# Altium schematic sheet RF210C.SchDoc(RF210C)
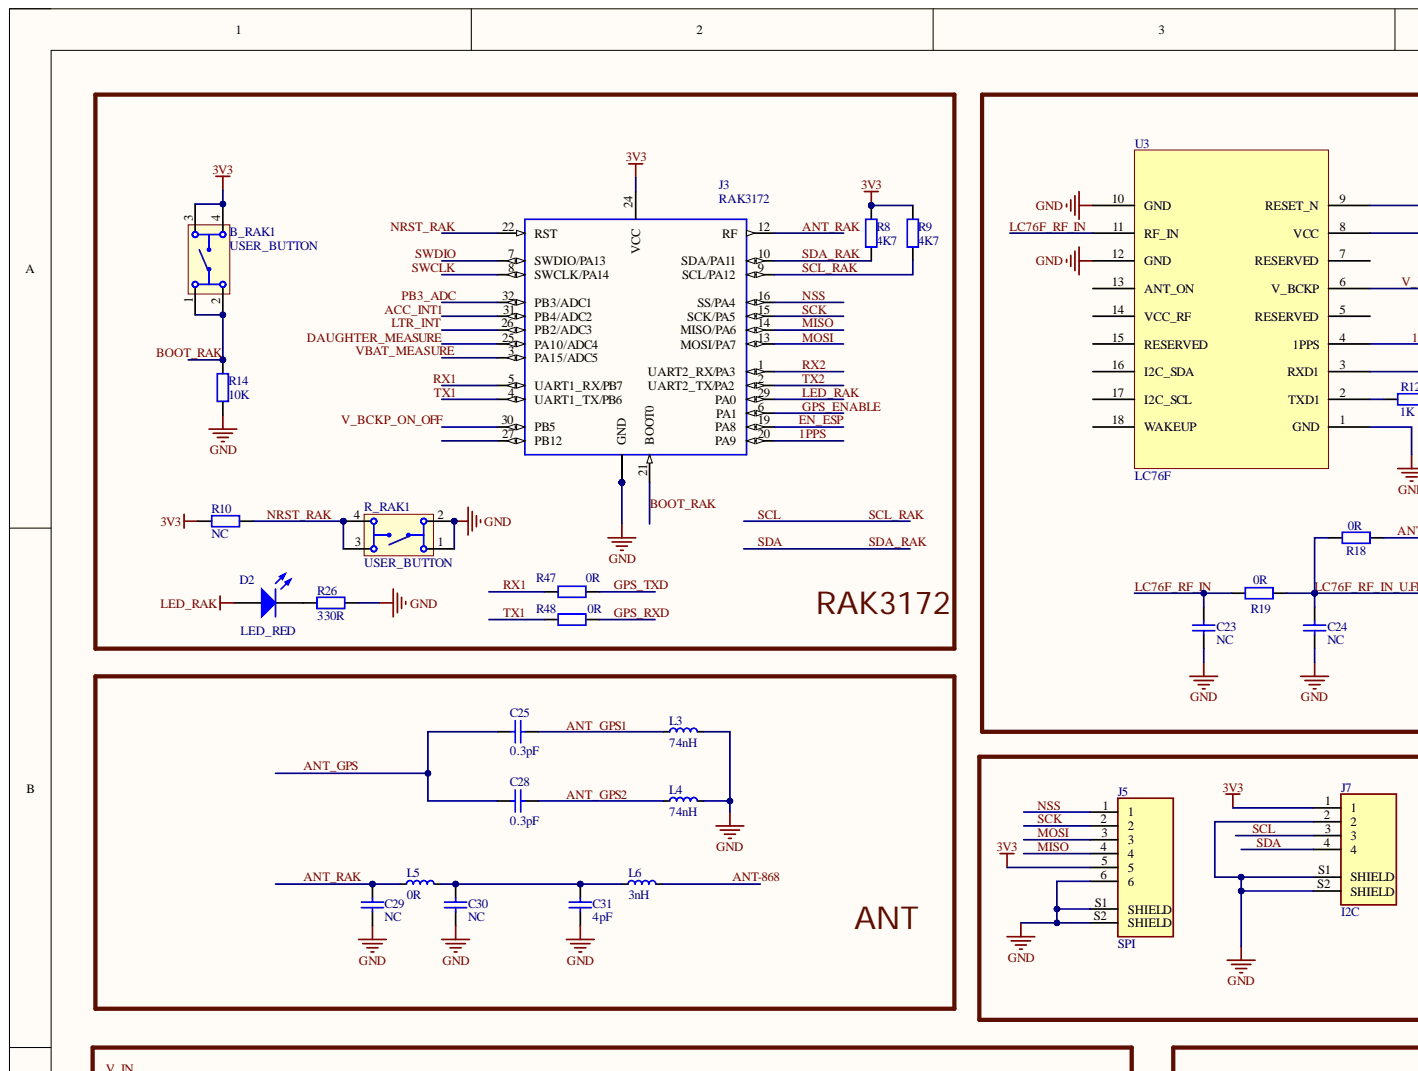
[diagram p0: RF210C.SchDoc, top left]
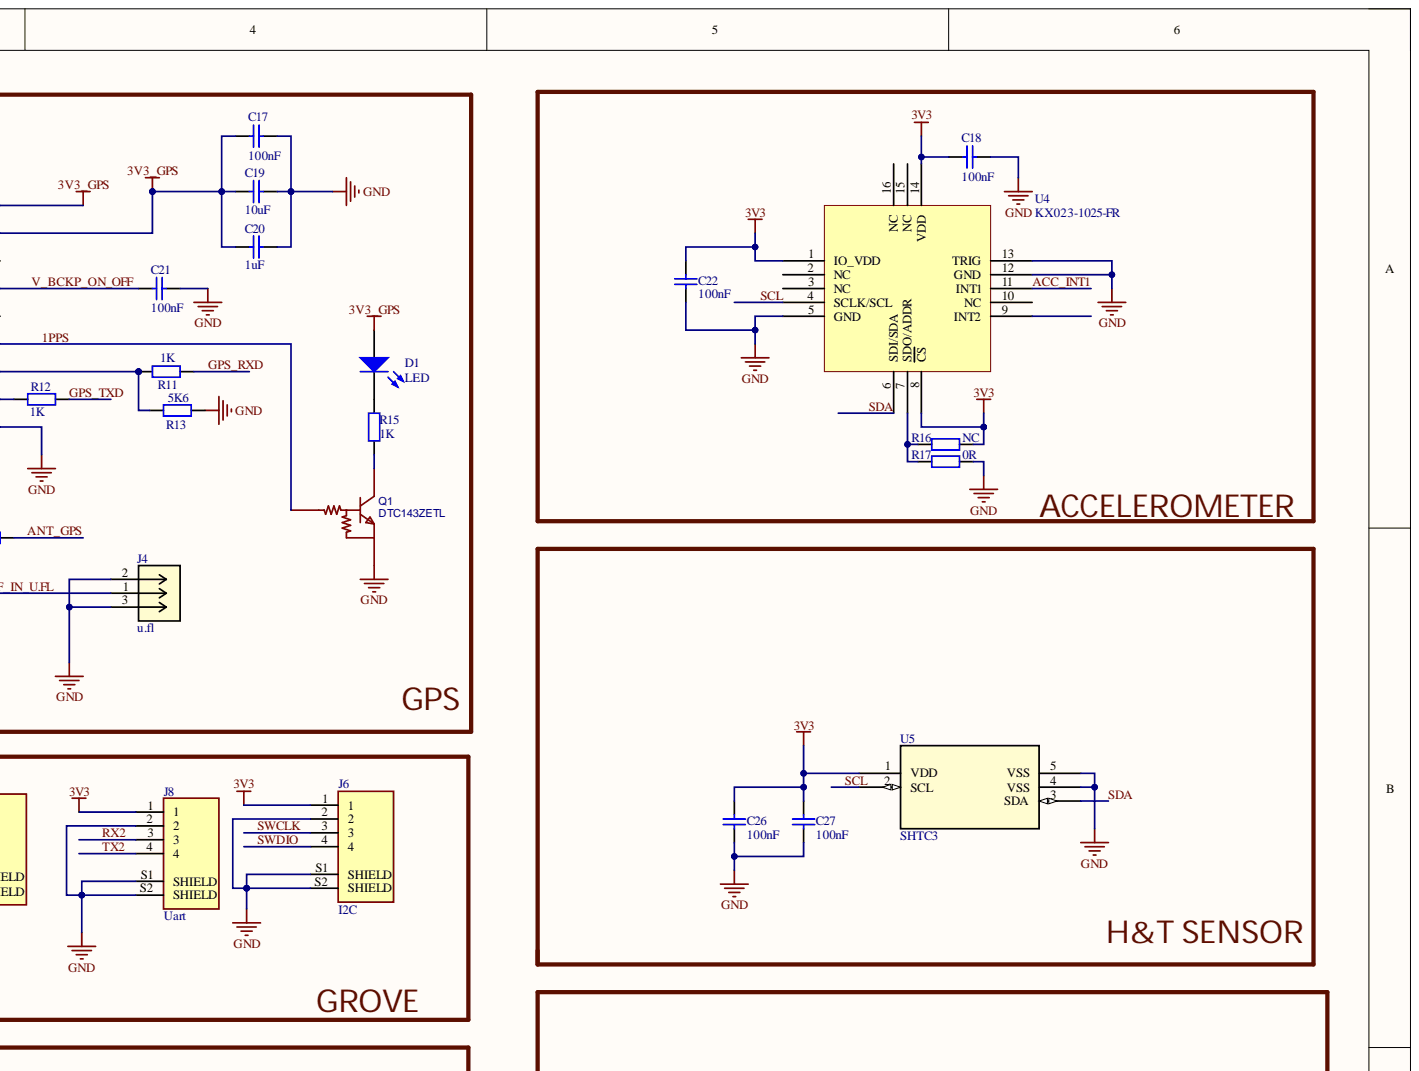
[diagram p1: RF210C.SchDoc, top right]
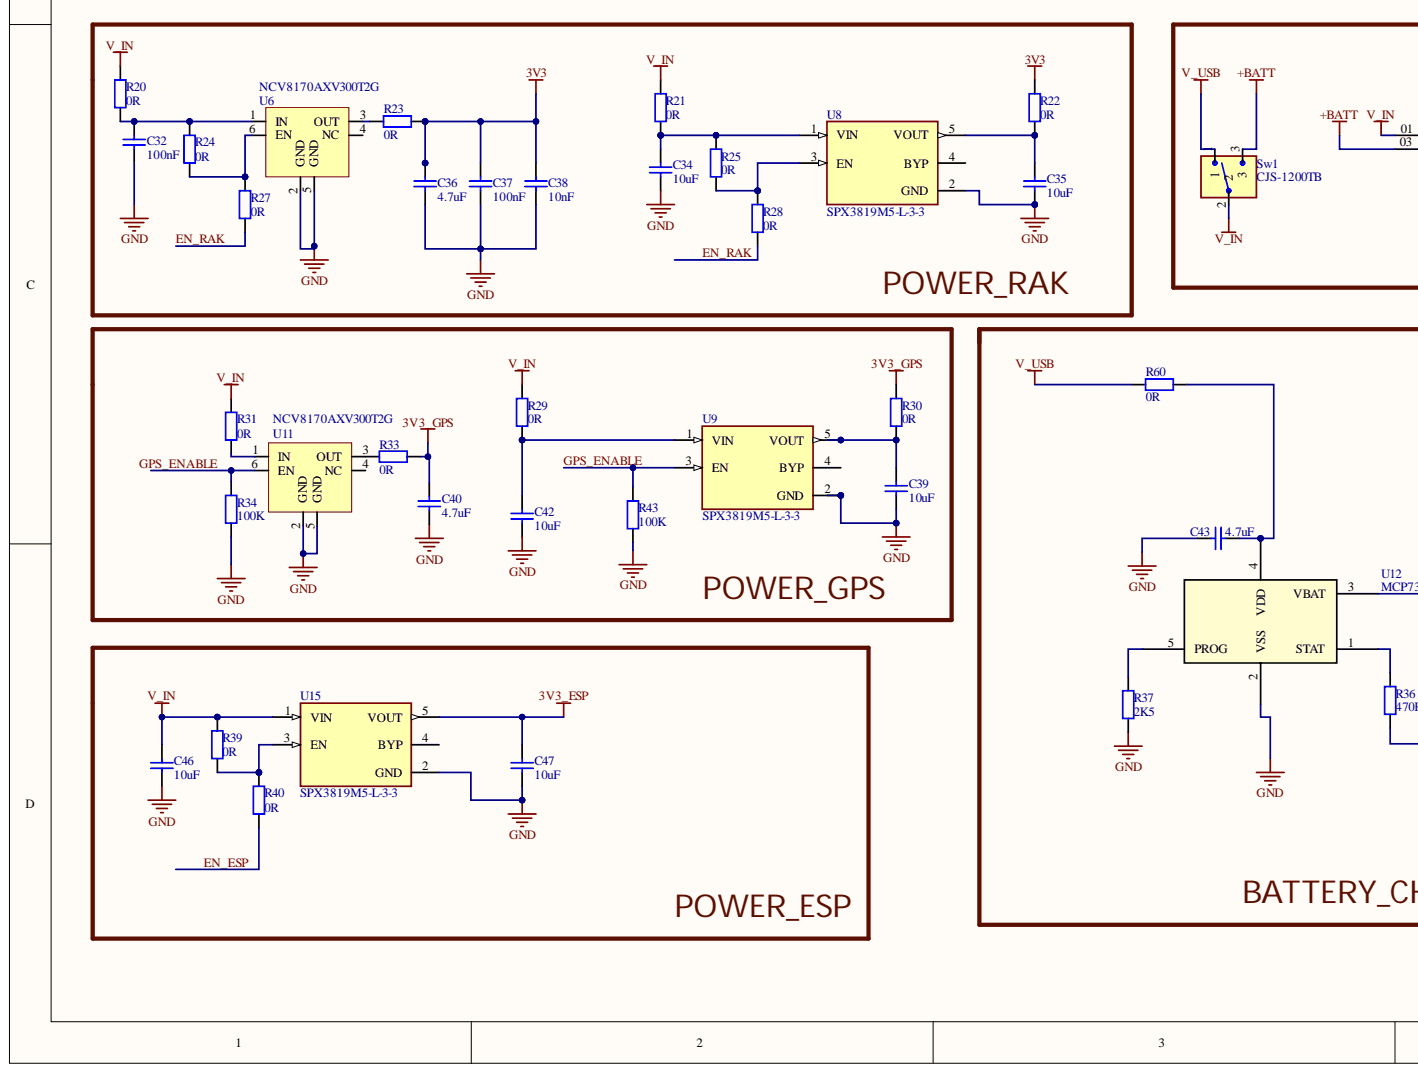
[diagram p2: RF210C.SchDoc, bottom left]
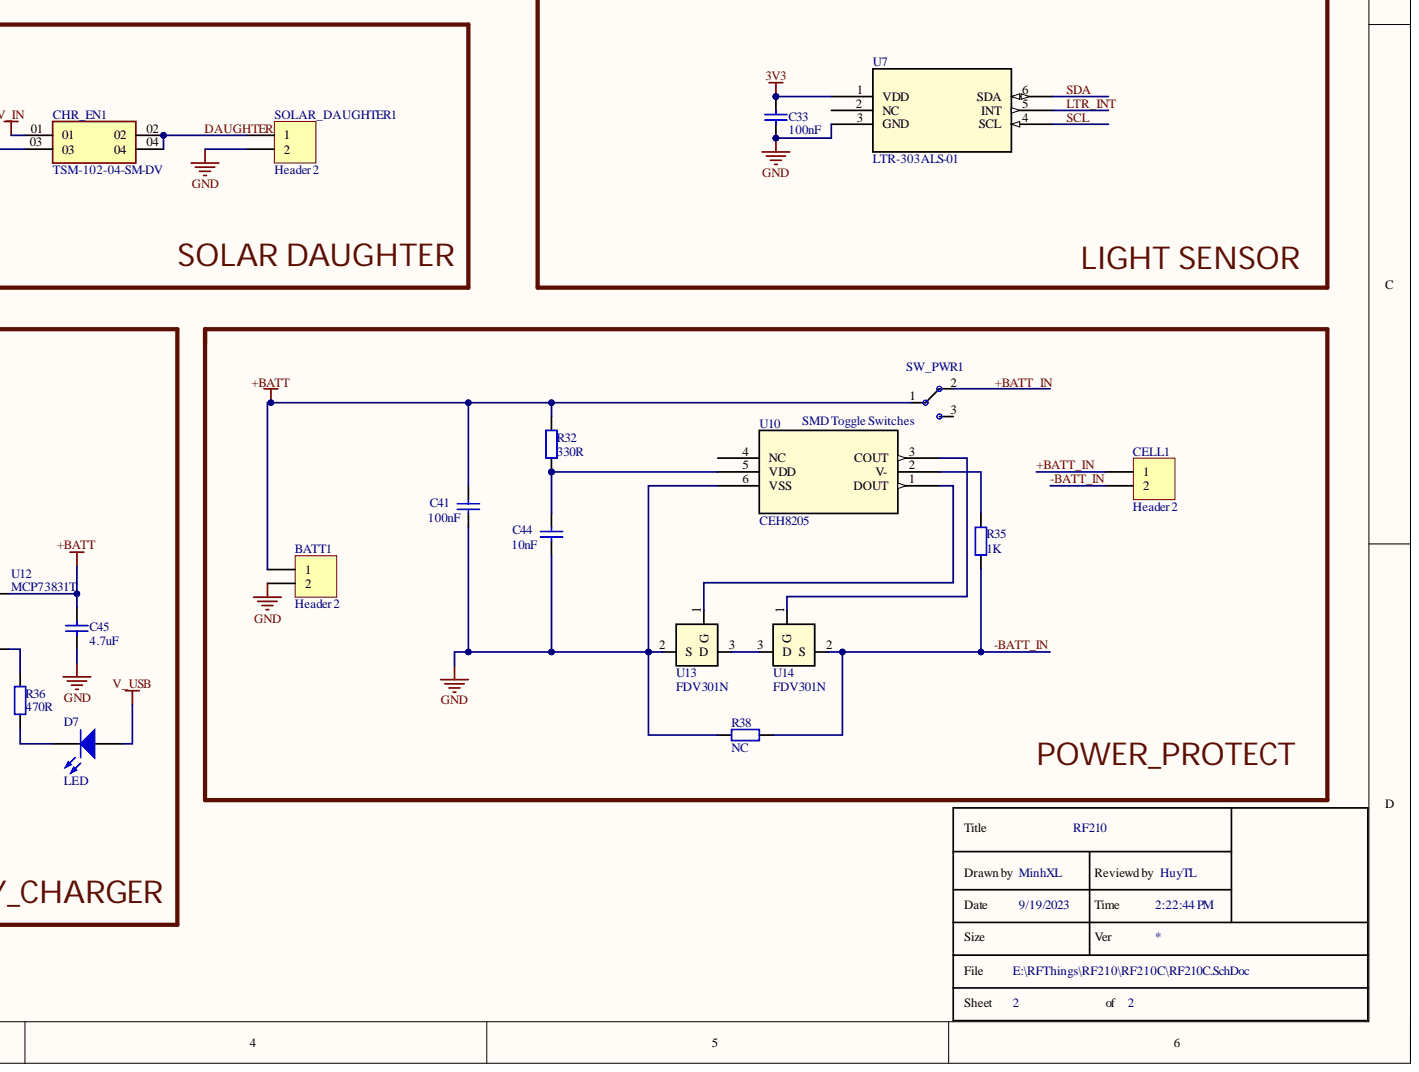
[diagram p3: RF210C.SchDoc, bottom right]

## Parts
*2  Title
B_RAK1  USER_BUTTON  [K2-1114UA-A4SW-06]
BATT1  Header 2  [HDR1X2]
C17  value 100nF  [rlc_402_smd]  (hidden comment: Cap)
C18  value 100nF  [rlc_402_smd]  (hidden comment: Cap)
C19  value 10uF  [rlc_603_smd]  (hidden comment: Cap)
C20  value 1uF  [rlc_402_smd]  (hidden comment: Cap)
C21  value 100nF  [rlc_402_smd]  (hidden comment: Cap)
C22  value 100nF  [rlc_402_smd]  (hidden comment: Cap)
C23  value NC  [rlc_402_smd]  (hidden comment: Cap)
C24  value NC  [rlc_402_smd]  (hidden comment: Cap)
C25  value 0.3pF  [rlc_402_smd]  (hidden comment: Cap)
C26  value 100nF  [rlc_402_smd]  (hidden comment: Cap)
C27  value 100nF  [rlc_402_smd]  (hidden comment: Cap)
C28  value 0.3pF  [rlc_402_smd]  (hidden comment: Cap)
C29  value NC  [rlc_402_smd]  (hidden comment: Cap)
C30  value NC  [rlc_402_smd]  (hidden comment: Cap)
C31  value 4pF  [rlc_402_smd]  (hidden comment: Cap)
C32  value 100nF  [rlc_402_smd]  (hidden comment: Cap)
C33  value 100nF  [rlc_402_smd]  (hidden comment: Cap)
C34  value 10uF  [rlc_402_smd]  (hidden comment: Cap)
C35  value 10uF  [rlc_402_smd]  (hidden comment: Cap)
C36  value 4.7uF  [rlc_402_smd]  (hidden comment: Cap)
C37  value 100nF  [rlc_402_smd]  (hidden comment: Cap)
C38  value 10nF  [rlc_402_smd]  (hidden comment: Cap)
C39  value 10uF  [rlc_402_smd]  (hidden comment: Cap)
C40  value 4.7uF  [rlc_402_smd]  (hidden comment: Cap)
C41  value 100nF  [rlc_402_smd]  (hidden comment: Cap)
C42  value 10uF  [rlc_402_smd]  (hidden comment: Cap)
C43  value 4.7uF  [rlc_402_smd]  (hidden comment: Cap)
C44  value 10nF  [rlc_402_smd]  (hidden comment: Cap)
C45  value 4.7uF  [rlc_402_smd]  (hidden comment: Cap)
C46  value 10uF  [rlc_402_smd]  (hidden comment: Cap)
C47  value 10uF  [rlc_402_smd]  (hidden comment: Cap)
CELL1  Header 2  [HDR1X2]
CHR_EN1  TSM-102-04-SM-DV  [SAMTEC_TSM-102-04-SM-DV]
D1  LED  [CD1005-0402]
D2  LED_RED  [CD1005-0402]
D7  LED  [CD1005-0402]
J3  RAK3172  [RAK3172]
J4  u.fl  [UFL Connector]
J5  SPI  [JST_BM06B-SRSS-TB(LF)(SN)]
J6  I2C  [CONN_BM04B-SRSS-TB(LF)(SN)]
J7  I2C  [CONN_BM04B-SRSS-TB(LF)(SN)]
J8  Uart  [CONN_BM04B-SRSS-TB(LF)(SN)]
L3  value 74nH  [rlc_402_smd]  (hidden comment: Inductor small symbol)
L4  value 74nH  [rlc_402_smd]  (hidden comment: Inductor small symbol)
L5  value 0R  [rlc_402_smd]  (hidden comment: Inductor small symbol)
L6  value 3nH  [rlc_402_smd]  (hidden comment: Inductor small symbol)
Q1  DTC143ZETL  [SOT-416]
R8  value 4K7  [rlc_402_smd]  (hidden comment: Resistor)
R9  value 4K7  [rlc_402_smd]  (hidden comment: Resistor)
R10  value NC  [rlc_402_smd]  (hidden comment: Resistor)
R11  value 1K  [rlc_402_smd]  (hidden comment: Resistor)
R12  value 1K  [rlc_402_smd]  (hidden comment: Resistor)
R13  value 5K6  [rlc_402_smd]  (hidden comment: Resistor)
R14  value 10K  [rlc_402_smd]  (hidden comment: Resistor)
R15  value 1K  [rlc_402_smd]  (hidden comment: Resistor)
R16  value NC  [rlc_402_smd]  (hidden comment: Resistor)
R17  value 0R  [rlc_402_smd]  (hidden comment: Resistor)
R18  value 0R  [rlc_402_smd]  (hidden comment: Resistor)
R19  value 0R  [rlc_402_smd]  (hidden comment: Resistor)
R20  value 0R  [rlc_402_smd]  (hidden comment: Resistor)
R21  value 0R  [rlc_402_smd]  (hidden comment: Resistor)
R22  value 0R  [rlc_402_smd]  (hidden comment: Resistor)
R23  value 0R  [rlc_402_smd]  (hidden comment: Resistor)
R24  value 0R  [rlc_402_smd]  (hidden comment: Resistor)
R25  value 0R  [rlc_402_smd]  (hidden comment: Resistor)
R26  value 330R  [rlc_402_smd]  (hidden comment: Resistor)
R27  value 0R  [rlc_402_smd]  (hidden comment: Resistor)
R28  value 0R  [rlc_402_smd]  (hidden comment: Resistor)
R29  value 0R  [rlc_402_smd]  (hidden comment: Resistor)
R30  value 0R  [rlc_402_smd]  (hidden comment: Resistor)
R31  value 0R  [rlc_402_smd]  (hidden comment: Resistor)
R32  value 330R  [rlc_402_smd]  (hidden comment: Resistor)
R33  value 0R  [rlc_402_smd]  (hidden comment: Resistor)
R34  value 100K  [rlc_402_smd]  (hidden comment: Resistor)
R35  value 1K  [rlc_402_smd]  (hidden comment: Resistor)
R36  value 470R  [rlc_402_smd]  (hidden comment: Resistor)
R37  value 2K5  [rlc_402_smd]  (hidden comment: Resistor)
R38  value NC  [rlc_402_smd]  (hidden comment: Resistor)
R39  value 0R  [rlc_402_smd]  (hidden comment: Resistor)
R40  value 0R  [rlc_402_smd]  (hidden comment: Resistor)
R43  value 100K  [rlc_402_smd]  (hidden comment: Resistor)
R47  value 0R  [rlc_402_smd]  (hidden comment: Resistor)
R48  value 0R  [rlc_402_smd]  (hidden comment: Resistor)
R60  value 0R  [rlc_402_smd]  (hidden comment: Resistor)
R_RAK1  USER_BUTTON  [K2-1114UA-A4SW-06]
SOLAR_DAUGHTER1  Header 2  [HDR1X2]
Sw1  CJS-1200TB  [B-TYPE]
SW_PWR1  SMD Toggle Switches  [MSK-12C02]
U3  LC76F  [LC76F]
U4  KX023-1025-FR  [KX023-1025-FR]
U5  SHTC3  [SHTC3]
U6  NCV8170AXV300T2G  [SOT-563]
U7  LTR-303ALS-01  [LTR-303ALS-01_LTO-M]
U8  SPX3819M5-L-3-3  [SOT95P280X145-5N]
U9  SPX3819M5-L-3-3  [SOT95P280X145-5N]
U10  CEH8205  [SOT-23-6_RIC]
U11  NCV8170AXV300T2G  [SOT-563]
U12  MCP73831T  [MCP73831T-2ATI-OT]
U13  FDV301N  [SOT23-3_ONS]
U14  FDV301N  [SOT23-3_ONS]
U15  SPX3819M5-L-3-3  [SOT95P280X145-5N]

## Nets
3V3: B_RAK1.3 B_RAK1.4 C18.2 C22.2 C26.2 C27.2 C33.2 C36.2 C37.2 C38.2 J3.24(VCC) J5.5 J6.1 J7.1 J8.1 R8.2 R9.2 R10.2 R16.2 R22.2 R23.1 U4.1(IO_VDD) U4.8(CS) U4.14(VDD) U5.1(VDD) U7.1(VDD)
3V3_ESP: C47.2 U15.5(VOUT)
3V3_GPS: C17.2 C19.1 C20.1 C40.2 D1.1 R30.1 R33.2 U3.8(VCC) U3.9(RESET_N)
+BATT: BATT1.1 C41.2 C45.2 CHR_EN1.03 R32.2 Sw1.3 SW_PWR1.1 U12.3(VBAT)
GND: BATT1.2 C17.1 C18.1 C19.2 C20.2 C21.1 C22.1 C23.1 C24.1 C26.1 C27.1 C29.1 C30.2 C31.2 C32.1 C33.1 C34.1 C35.1 C36.1 C37.1 C38.1 C39.1 C40.1 C41.1 C42.1 C43.1 C44.1 C45.1 C46.1 C47.1 J3.11(GND) J3.17 J3.18 J3.23 J3.28 J4.2 J4.3 J5.6 J5.S1(SHIELD) J5.S2(SHIELD) J6.2 J6.S1(SHIELD) J6.S2(SHIELD) J7.2 J7.S1(SHIELD) J7.S2(SHIELD) J8.2 J8.S1(SHIELD) J8.S2(SHIELD) L3.2 L4.2 Q1.1 R13.1 R14.1 R17.1 R26.1 R34.1 R37.1 R38.2 R43.1 R_RAK1.1 R_RAK1.2 SOLAR_DAUGHTER1.2 U3.1(GND) U3.10(GND) U3.12(GND) U4.5(GND) U4.12(GND) U4.13(TRIG) U5.4(VSS) U5.5(VSS) U6.2(GND) U6.5(GND) U7.3(GND) U8.2(GND) U9.2(GND) U10.6(VSS) U11.2(GND) U11.5(GND) U12.2(VSS) U13.2 U15.2(GND)
V_IN: C46.2 CHR_EN1.01 R20.2 R21.1 R29.1 R31.1 R39.2 Sw1.2 U15.1(VIN)
V_USB: D7.1 R60.1 Sw1.1
1PPS: J3.20(PA9) Q1.2 U3.4(1PPS)
+BATT_IN: CELL1.1 SW_PWR1.2
-BATT_IN: CELL1.2 R35.1 R38.1 U14.2
ACC_INT1: J3.31(PB4/ADC2) U4.11(INT1)
ANT-868: L6.2
ANT_GPS: C25.1 C28.1 R18.1
ANT_GPS1: C25.2 L3.1
ANT_GPS2: C28.2 L4.1
ANT_RAK: C29.2 J3.12(RF) L5.1
BOOT_RAK: B_RAK1.1 B_RAK1.2 J3.21(BOOT0) R14.2
DAUGHTER: CHR_EN1.02 CHR_EN1.04 SOLAR_DAUGHTER1.1
DAUGHTER_MEASURE: J3.25(PA10/ADC4)
EN_ESP: J3.19(PA8) R40.1
EN_RAK: R27.1 R28.1
GPS_ENABLE: J3.6(PA1) R34.2 R43.2 U9.3(EN) U11.6(EN)
GPS_RXD: R11.1 R48.1
GPS_TXD: R12.1 R47.1
LC76F_RF_IN: C23.2 R19.2 U3.11(RF_IN)
LC76F_RF_IN_U.FL: C24.2 J4.1 R18.2 R19.1
LED_RAK: D2.1 J3.29(PA0)
LTR_INT: J3.26(PB2/ADC3) U7.5(INT)
MISO: J3.14(MISO/PA6) J5.4
MOSI: J3.13(MOSI/PA7) J5.3
NRST_RAK: J3.22(RST) R10.1 R_RAK1.3 R_RAK1.4
NSS: J3.16(SS/PA4) J5.1
PB3_ADC: J3.32(PB3/ADC1)
RX1: J3.5(UART1_RX/PB7) R47.2
RX2: J3.1(UART2_RX/PA3) J8.3
SCK: J3.15(SCK/PA5) J5.2
SCL: J3.9(SCL/PA12) J7.3 R9.1 U4.4(SCLK/SCL) U5.2(SCL) U7.4(SCL)
SDA: J3.10(SDA/PA11) J7.4 R8.1 U4.6(SDI/SDA) U5.3(SDA) U7.6(SDA)
SWCLK: J3.8(SWCLK/PA14) J6.3
SWDIO: J3.7(SWDIO/PA13) J6.4
TX1: J3.4(UART1_TX/PB6) R48.2
TX2: J3.2(UART2_TX/PA2) J8.4
V_BCKP_ON_OFF: C21.2 J3.30(PB5) U3.6(V_BCKP)
VBAT_MEASURE: J3.3(PA15/ADC5)
NetC30_1: C30.1 C31.1 L5.2 L6.1
NetC32_2: C32.2 R20.1 R24.2 U6.1(IN)
NetC34_2: C34.2 R21.2 R25.2 U8.1(VIN)
NetC35_2: C35.2 R22.1 U8.5(VOUT)
NetC39_2: C39.2 R30.2 U9.5(VOUT)
NetC42_2: C42.2 R29.2 U9.1(VIN)
NetC43_2: C43.2 R60.2 U12.4(VDD)
NetC44_2: C44.2 R32.1 U10.5(VDD)
NetD1_2: D1.2 R15.1
NetD2_2: D2.2 R26.2
NetD7_2: D7.2 R36.1
NetQ1_3: Q1.3 R15.2
NetR11_2: R11.2 R13.2 U3.3(RXD1)
NetR12_2: R12.2 U3.2(TXD1)
NetR16_1: R16.1 R17.2 U4.7(SDO/ADDR)
NetR23_2: R23.2 U6.3(OUT)
NetR24_1: R24.1 R27.2 U6.6(EN)
NetR25_1: R25.1 R28.2 U8.3(EN)
NetR31_2: R31.2 U11.1(IN)
NetR33_1: R33.1 U11.3(OUT)
NetR35_2: R35.2 U10.2(V-)
NetR36_2: R36.2 U12.1(STAT)
NetR37_2: R37.2 U12.5(PROG)
NetR39_1: R39.1 R40.2 U15.3(EN)
NetU10_1: U10.1(DOUT) U13.1
NetU10_3: U10.3(COUT) U14.1
NetU13_3: U13.3 U14.3

Not connected: J3 27(PB12); SW_PWR1 3; U3 5(RESERVED) 7(RESERVED) 13(ANT_ON) 14(VCC_RF) 15(RESERVED) 16(I2C_SDA) 17(I2C_SCL) 18(WAKEUP); U4 2(NC) 3(NC) 9(INT2) 10(NC) 15(NC) 16(NC); U6 4(NC); U7 2(NC); U8 4(BYP); U9 4(BYP); U10 4(NC); U11 4(NC); U15 4(BYP)

## Library data of the parts
B_RAK1 R_RAK1 = K2-1114UA-A4SW-06: 3.6mm Rectangle button 50mA Surface Mount 4.8mm SPST 12V SMD,4.8x3.6x1.65mm Tactile Switches ROHS; Manufacturer: Wuerth Elektronik; PartNumber: 436333045822; Component Kind: Standard; ComponentLink1Description: Manufacturer URL; ComponentLink1URL: http://www.we-online.com; ComponentLink2Description: Datasheet; ComponentLink2URL: http://katalog.we-online.de/em/datasheet/436333045822.pdf; Library Name: K2-1114UA-A4SW-06.SchLib; PackageReference: 436333045822
BATT1 CELL1 SOLAR_DAUGHTER1 = Header 2: Header, 2-Pin; Component Kind: Standard; LatestRevisionDate: 17-Jul-2002; LatestRevisionNote: Re-released for DXP Platform.; Library Name: LR1110_e516v02b.SchLib; Signal Integrity: Connector; Publisher: Altium Limited
C17-C47 = Cap: Capacitor SMD; Component Kind: Standard; LatestRevisionDate: 05-oct-2007  -  Semtech; LatestRevisionNote: Re-released for DXP Platform.; Published: 8-Jun-2000; Signal Integrity: Cap Semi; Simulation: CAP; Publisher: Altium Limited
  C17-C19 C22 C25-C47: Library Name: LR1110_e516v02b.SchLib
  C20 C21 C23 C24: Library Name: Gemini_LR1120.IntLib
CHR_EN1 = TSM-102-04-SM-DV: Connector Header Surface Mount 4 position 0.100 (2.54mm); MF: Samtec; MP: TSM-102-04-SM-DV-P-TR; Availability: In Stock; Check_prices: https://www.snapeda.com/parts/TSM-102-04-SM-DV-P-TR/Samtec/view-part/?ref=eda; Component Kind: Standard; Library Name: TSM-102-04-SM-DV.IntLib; Package: None; Price: None; Purchase-URL: https://pricing.snapeda.com/search/part/TSM-102-04-SM-DV-P-TR/?ref=eda; SnapEDA_Link: https://www.snapeda.com/parts/TSM-102-04-SM-DV-P-TR/Samtec/view-part/?ref=snap
D2 = LED: Component Kind: Standard; Description: LED; LatestRevisionDate: 05-oct-2007  -  Semtech; Library Name: LR1110_e516v02b.SchLib
J3 = RAK3172: RAK3172 is a low-power long-range transceiver module based on the STM32WLE5CC chip. It provides an easy-to-use, small-size, low-power solution for long range wireless data applications; MF: Shenzhen RAKwireless Technology Co.,Ltd.; Availability: Not in stock; Check_prices: https://www.snapeda.com/parts/RAK3172/Shenzhen+RAKwireless+Technology+Co.%252CLtd./view-part/?ref=eda; Component Kind: Standard; Library Name: RAK3172.IntLib; MP: RAK3172; Package: Package; Price: None; SnapEDA_Link: https://www.snapeda.com/parts/RAK3172/Shenzhen+RAKwireless+Technology+Co.%252CLtd./view-part/?ref=snap
J4 = U.FL-R-SMT: No Description Available; Manufacturer_Part_Number: U.FL-R-SMT-1(10); Built_By: EMA_Matt; Vendor: Hirose; Component Kind: Standard; Library Name: MyLibrary-001.SchLib; RefDes: RefDes; Type: DEV; Value: Value; Copyright: Copyright (C) 2018 Accelerated Designs. All rights reserved
J5 = BM06B-SRSS-TB(LF)(SN): Connector Header Surface Mount 6 position 0.039 (1.00mm); MF: JST Sales; Availability: Unavailable; Component Kind: Standard; Library Name: BM06B-SRSS-TB_LF__SN_.IntLib; MP: BM06B-SRSS-TB(LF)(SN); Package: None; Price: None; SnapEDA_Link: https://www.snapeda.com/parts/BM06B-SRSS-TB(LF)(SN)/JST%20Sales%20America%20Inc./view-part/317877/?ref=snap
J6-J8 = BM04B-SRSS-TB(LF)(SN): BM04B Series 1 mm Pitch 4 Position SMT Single Row Top Entry Shrouded Header; Availability: Unavailable; Component Kind: Standard; Library Name: BM02B-SRSS-TB_LF__SN_.IntLib; MP: BM04B-SRSS-TB(LF)(SN); Package: None; Price: None
L3-L6 = Inductor_small: Inductor; Code_IEC: 1005; Code_JEITA: 0402; Component Kind: Standard; LatestRevisionDate: 05-oct-2007  -  Semtech; LatestRevisionNote: Re-released for DXP Platform.; Library Name: LR1110_e516v02b.SchLib; Published: 8-Jun-2000; Publisher: Altium Limited
Q1 = DTC143ZETL: NPN - Pre-Biased 150mW 100mA 50V SOT-416 Digital Transistors ROHS; BOM_Manufacturer: ROHM; BOM_JLCPCB Part Class: Extended Part; BOM_Manufacturer Part: DTC143ZETL; BOM_Supplier: LCSC; BOM_Supplier Part: C111728; Component Kind: Standard; Library Name: DTC143ZETL.IntLib; spicePre: U; spiceSymbolName: DTC143ZETL
R8-R40 R43 R47 R48 R60 = Resistor: Resistor SMD - IEC symbol version; Component Kind: Standard; LatestRevisionDate: 27-Jul-20  -  Semtech
  R9: Library Name: TXB0104RUTR.IntLib
  R10 R14 R16 R17 R20-R34 R36 R37 R39 R40 R43 R60: Library Name: LR1110_e516v02b.SchLib
  R8 R11-R13 R15 R18 R19 R35 R38 R47 R48: Library Name: Gemini_LR1120.IntLib
Sw1 = CJS-1200TB: Slide Switch SPDT Surface Mount; MF: Nidec Copal; Availability: In Stock; Check_prices: https://www.snapeda.com/parts/CJS-1200TB/Nidec+Copal+Electronics/view-part/?ref=eda; Component Kind: Standard; Library Name: CJS-1200TB.IntLib; MP: CJS-1200TB; Package: None; Price: None; Purchase-URL: https://pricing.snapeda.com/search/part/CJS-1200TB/?ref=eda; SnapEDA_Link: https://www.snapeda.com/parts/CJS-1200TB/Nidec+Copal+Electronics/view-part/?ref=snap
SW_PWR1 = MSK-12C02: Component Kind: Standard; Description: SMD Toggle Switches; Library Name: MSK-12C02.IntLib
U4 = KX023-1025-FR: Accelerometer X, Y, Z Axis ±2g, 4g, 8g 0.39Hz ~ 800Hz 16-LGA (3x3); Manufacture: Kionix Inc.; Component Kind: Standard; Digikey: 1191-1039-1-ND; LCSC: C236128; Library Name: KX023-1025-FR.IntLib; MPN: KX023-1025-FR
U5 = SHTC3: No Description Available; Mfr_Name: Sensirion; Component Kind: Standard; Library Name: SHTC3.SchLib; Manufacturer_Part_Number: SHTC3; RefDes: RefDes; Type: DEV; Copyright: Copyright (C) 2022 Ultra Librarian. All rights reserved.
U6 U11 = NCV8170: Linear Voltage Regulator IC Positive Fixed 1 Output  150mA SOT-563-6; Component Kind: Standard; Library Name: MyLibrary-001.SchLib
U7 = LTR-303ALS-01: No Description Available; Mfr_Name: LITE-ON; Component Kind: Standard; Library Name: LTR-303ALS-01.SchLib; Manufacturer_Part_Number: LTR-303ALS-01; RefDes: RefDes; Type: DEV; Copyright: Copyright (C) 2022 Ultra Librarian. All rights reserved.
U8 U9 U15 = SPX3819M5-L-3-3: Linear Voltage Regulator IC Positive Fixed 1 Output 500mA SOT-23-5; MF: MaxLinear, Inc.; MP: SPX3819M5-L-3-3/TR; Availability: In Stock; Check_prices: https://www.snapeda.com/parts/SPX3819M5-L-3-3/TR/MaxLinear%252C+Inc./view-part/?ref=eda; Component Kind: Standard; Library Name: SPX3819M5-L-3-3.IntLib; Package: SOT-23-5 Exar; Price: None; Purchase-URL: https://pricing.snapeda.com/search/part/SPX3819M5-L-3-3/TR/?ref=eda; SnapEDA_Link: https://www.snapeda.com/parts/SPX3819M5-L-3-3/TR/MaxLinear%252C+Inc./view-part/?ref=snap
U10 = R5478N101CD-TR-FF: No Description Available; Built_By: EMA_Cory; SourceLibrary: Ricoh_2020-07-16; Vendor: Ricoh Electronics; Component Kind: Standard; Datasheet: https://www.mouser.com/datasheet/2/792/r5478-ea-1770681.pdf; Library Name: R5478N101CDTRFF.IntLib; Manufacturer_Part_Number: R5478N101CD-TR-FF; RefDes: RefDes; Type: DEV; Copyright: Copyright (C) 2018 Accelerated Designs. All rights reserved
U12 = MCP73831T-2ATI/O: No Description Available; Manufacturer_Part_Number: MCP73831T-2ATI/OT; Built_By: EMA_Jessica; Vendor: Microchip; Component Kind: Standard; Library Name: MyLibrary-001.SchLib; RefDes: RefDes; Type: DEV; Value: Value; Copyright: Copyright (C) 2018 Accelerated Designs. All rights reserved
U13 U14 = FDV301N: No Description Available; Built_By: EMA_Jessica; SourceLibrary: ON_Semiconductor_2019-12-30; Vendor: ON Semiconductor; Component Kind: Standard; Library Name: FDV301N.SchLib; Manufacturer_Part_Number: FDV301N; RefDes: RefDes; Type: DEV; Copyright: Copyright (C) 2018 Accelerated Designs. All rights reserved
*2 = Title: Component Kind: Standard; Library Name: title.SchLib
D1 D7 = LED: Component Kind: Standard; Description: LED; LatestRevisionDate: 05-oct-2007  -  Semtech; Library Name: LR1110_e516v02b.SchLib
U3 = LC76F: Component Kind: Standard; Library Name: LC76F.IntLib
